# Altium schematic sheet REUN.468349.001_PSU_Board_Logic.SchDoc
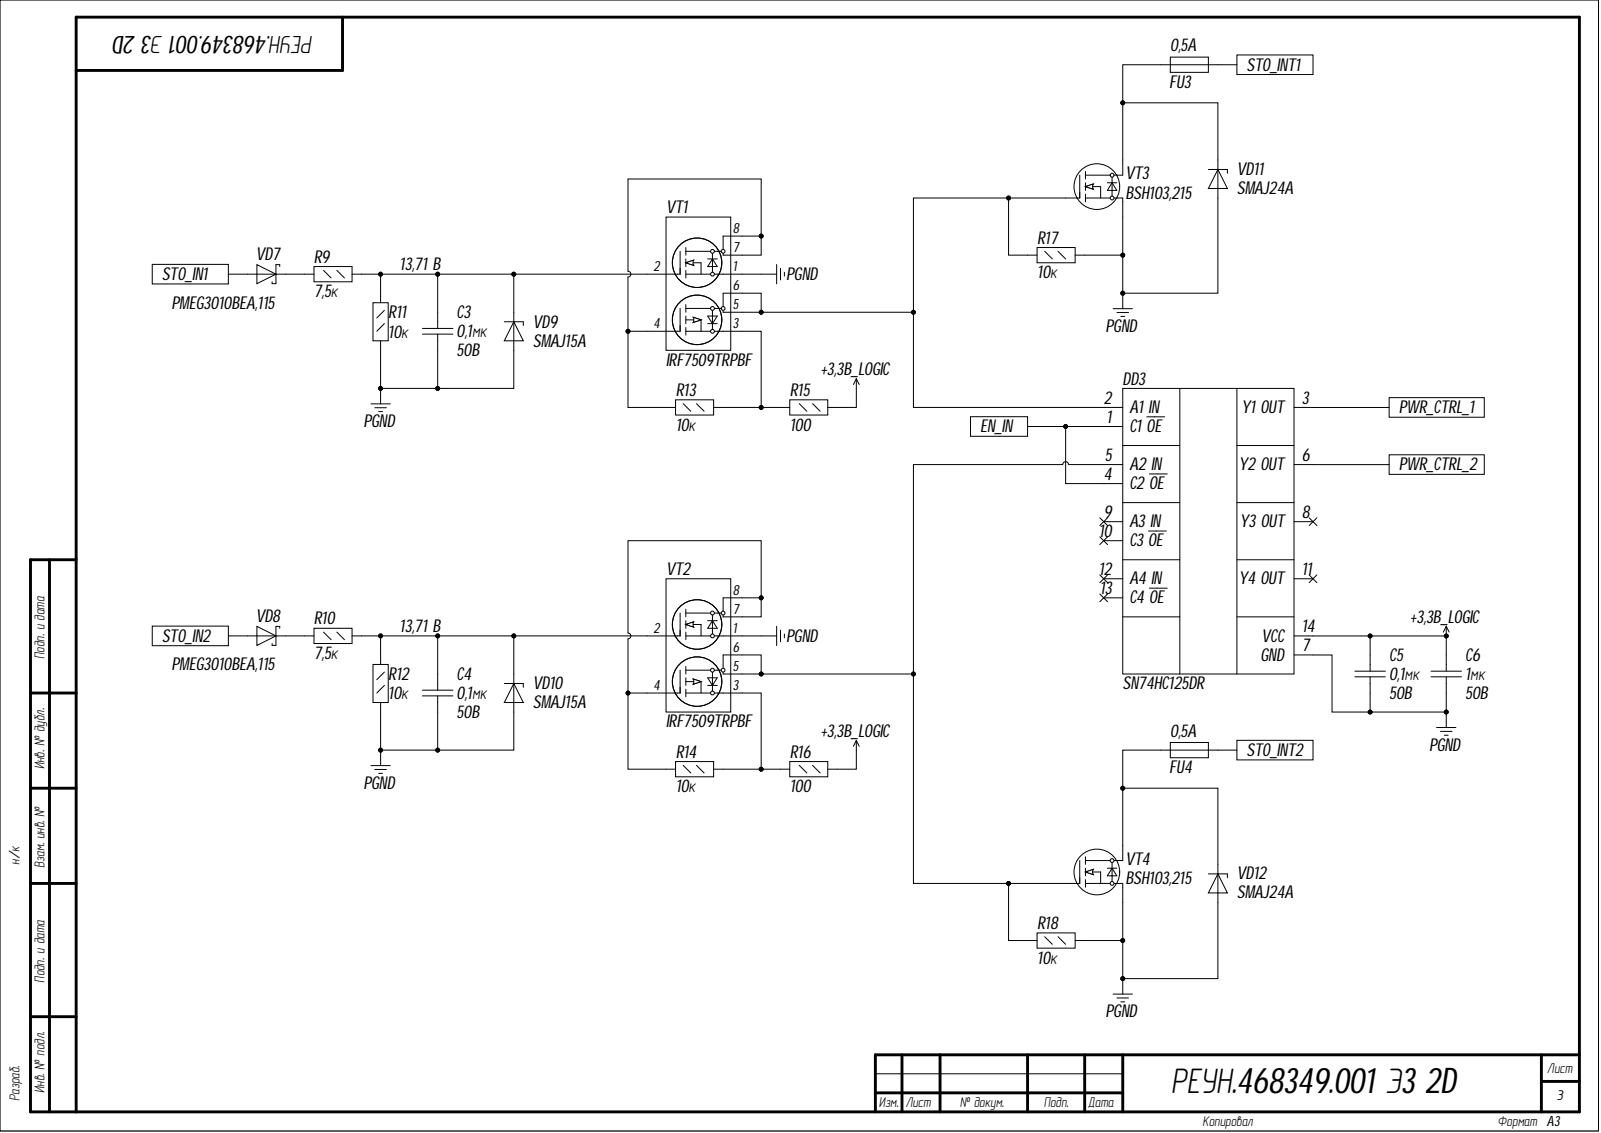
[diagram p0: REUN.468349.001_PSU_Board_Logic.SchDoc]

## Parts
C3  0,1мк  Voltage: 50B  [C0805]
C4  0,1мк  Voltage: 50B  [C0805]
C5  0,1мк  Voltage: 50B  [C0805]
C6  1мк  Voltage: 50B  [C0805]
DD3  SN74HC125DR  [SO14]
FU3  I hold: 0,5A  [FUSE812]  (hidden comment: Fuse)
FU4  I hold: 0,5A  [FUSE812]  (hidden comment: Fuse)
R9  value 7,5к  [R0805]  (hidden comment: Resistor_0,125Вт)
R10  value 7,5к  [R0805]  (hidden comment: Resistor_0,125Вт)
R11  value 10к  [R0805]  (hidden comment: Resistor_0,125Вт)
R12  value 10к  [R0805]  (hidden comment: Resistor_0,125Вт)
R13  value 10к  [R0805]  (hidden comment: Resistor_0,125Вт)
R14  value 10к  [R0805]  (hidden comment: Resistor_0,125Вт)
R15  value 100  [R0805]  (hidden comment: Resistor_0,125Вт)
R16  value 100  [R0805]  (hidden comment: Resistor_0,125Вт)
R17  value 10к  [R0805]  (hidden comment: Resistor_0,125Вт)
R18  value 10к  [R0805]  (hidden comment: Resistor_0,125Вт)
VD7  PMEG3010BEA,115  [SOD-323]
VD8  PMEG3010BEA,115  [SOD-323]
VD9  SMAJ15A  [SMA]
VD10  SMAJ15A  [SMA]
VD11  SMAJ24A  [SMA]
VD12  SMAJ24A  [SMA]
VT1  IRF7509TRPBF  [Micro8]
VT2  IRF7509TRPBF  [Micro8]
VT3  BSH103,215  [SOT23-3]
VT4  BSH103,215  [SOT23-3]

## Ports
EN_IN, PWR_CTRL_1, PWR_CTRL_2, STO_IN1, STO_IN2, STO_INT1, STO_INT2

## Nets
+3,3B_LOGIC: C5.2 C6.2 DD3.14(VCC) R15.1 R16.1
PGND: C3.1 C4.1 C5.1 C6.1 DD3.7(GND) R11.2 R12.2 R17.2 R18.2 VD9.2 VD10.2 VD11.2 VD12.2 VT1.1 VT2.1 VT3.2 VT4.2
EN_IN: DD3.1(C1_OE) DD3.4(C2_OE) ; port EN_IN
PWR_CTRL_1: DD3.3(Y1_OUT) ; port PWR_CTRL_1
PWR_CTRL_2: DD3.6(Y2_OUT) ; port PWR_CTRL_2
STO_IN1: VD7.2 ; port STO_IN1
STO_IN2: VD8.2 ; port STO_IN2
STO_INT1: FU3.2 ; port STO_INT1
STO_INT2: FU4.2 ; port STO_INT2
NetC3_2: C3.2 R9.1 R11.1 VD9.1 VT1.2
NetC4_2: C4.2 R10.1 R12.1 VD10.1 VT2.2
NetDD3_2: DD3.2(A1_IN) R17.1 VT1.5 VT1.6 VT3.1
NetDD3_5: DD3.5(A2_IN) R18.1 VT2.5 VT2.6 VT4.1
NetFU3_1: FU3.1 VD11.1 VT3.3
NetFU4_1: FU4.1 VD12.1 VT4.3
NetR9_2: R9.2 VD7.1
NetR10_2: R10.2 VD8.1
NetR13_1: R13.1 VT1.4 VT1.7 VT1.8
NetR13_2: R13.2 R15.2 VT1.3
NetR14_1: R14.1 VT2.4 VT2.7 VT2.8
NetR14_2: R14.2 R16.2 VT2.3

Not connected: DD3 8(Y3_OUT) 9(A3_IN) 10(C3_OE) 11(Y4_OUT) 12(A4_IN) 13(C4_OE)

## Library data of the parts
C3-C5 = cap141: Конденсатор; Manufacture: Murata; Part Name: Ceramic; Part Number: GRM21BR71H104KA01K; Database Table Name: Capacitors$; TKE: X7R; Component Kind: Standard; id: cap141; Library Name: MOP44.DbLib; Value: 0,1мк
C6 = cap151: Конденсатор; Manufacture: Murata; Part Name: Ceramic; Part Number: GRM21BR71H105KA12L; Database Table Name: Capacitors$; TKE: X7R; Component Kind: Standard; id: cap151; Library Name: MOP44.DbLib; Value: 1мк
DD3 = logic16: Quad Tri-State Buffer IC; Manufacture: Nexperia; Database Table Name: Logic$; Input High Level: 1,75B; Input Low Level: 1,55B; Number of elements: 4; Output High Level: 3,3B; Output Low Level: 0,1B; Power Supply: 2В-6В; Toff: 41ns; Type of Logic: 3V3 CMOS; Component Kind: Standard; id: logic16; Library Name: MOP44.DbLib; Part Name: Quad Tri-State Buffer; Part Number: SN74HC125DR; Temperature: -40...+125; Ton: 41ns
FU3 FU4 = fuse8: Предохранитель; Manufacture: Bourns; Part Name: Resettable Fuses; Part Number: MF-MSMF050; Database Table Name: Fuse$; I max: 100A; I trip: 1A; Resistance: 0,15Ohms; V max: 15B; Component Kind: Standard; id: fuse8; Library Name: MOP44.DbLib
R9 R10 = res98: Резистор; Manufacture: Yageo; Part Number: RC0805FR-077K5; Accuracy: 0.01; Database Table Name: Resistor$; Component Kind: Standard; id: res98; Library Name: MOP44.DbLib; Part Name: Resistor; Power: 0,125Вт
R11-R14 R17 R18 = res101: Резистор; Manufacture: Yageo; Part Number: RC0805FR-0710KL; Accuracy: 0.01; Database Table Name: Resistor$; Component Kind: Standard; id: res101; Library Name: MOP44.DbLib; Part Name: Resistor; Power: 0,125Вт
R15 R16 = res49: Резистор; Manufacture: Yageo; Part Number: RC0805FR-07100RL; Accuracy: 0.01; Database Table Name: Resistor$; Component Kind: Standard; id: res49; Library Name: MOP44.DbLib; Part Name: Resistor; Power: 0,125Вт
VD7 VD8 = diode63: Диод Шоттки; Manufacture: NXP Semiconductor; Part Name: Diode Schottky; Database Table Name: Diode$; Forward Current: 1А; Forward Voltage: 0,13B; Max Reverse Voltage: 30B; Number: 1; Peak Current: 10A; Reverse Current: 1,5mA; Component Kind: Standard; id: diode63; Library Name: MOP44.DbLib; Part Number: PMEG3010BEA,115; Temp MAX: +150; Temp MIN: -65
VD9 VD10 = SMAJ15A: Cтабилитрон (лавинный выпрямительный диод) односторонний; Manufacture: ST Microelectronics; Part Name: TVS diode Unidirectional; Breakdown Voltage: 17,6B; Clamping voltage: 24,4B; Database Table Name: TVSdiode$; Dynamic resistance: 0,361Ohm; id: tvs130; Leakage Current: 0,2uA; Number of diodes: 1; Peak Pulse Power: 400W; Stand-off Voltage: 15B; Component Kind: Standard; Library Name: MOP44.DbLib; Part Number: SMAJ15A
VD11 VD12 = SMAJ24A: Cтабилитрон (лавинный выпрямительный диод) односторонний; Manufacture: ST Microelectronics; Part Name: TVS diode Unidirectional; Breakdown Voltage: 28,1B; Clamping voltage: 38,9B; Database Table Name: TVSdiode$; Dynamic resistance: 0,912Ohm; id: tvs134; Leakage Current: 0,2uA; Number of diodes: 1; Peak Pulse Power: 400W; Stand-off Voltage: 24B; Component Kind: Standard; Library Name: MOP44.DbLib; Part Number: SMAJ24A
VT1 VT2 = mosfert3: Комплементарная сборка МОП; Manufacturer: International Rectifier; Continuous drain current: 2A; Database Table Name: MOSFETTransistor$; Gate-to-source voltage: (+-)20B; Power dissipation: 1,25W; Pulsed drain current: 16A; Rds: 0,11 Ohm; Structure: n-p-channel; V drain-source max: 30B; Component Kind: Standard; id: mosfert3; Library Name: MOP44.DbLib; Part Number: IRF7509TRPBF
VT3 VT4 = mosfert36: N-MOSFET; Manufacturer: Nexperia; Continuous drain current: 0,85A; Gate-to-source voltage: (+-)8B; Power dissipation: 0,5W; Pulsed drain current: 3,4A; Rds: 0,5Ohm; Structure: n-channel; Total gate charge: 2100pC; V drain-source max: 30B; Component Kind: Standard; id: mosfert36; Part Number: BSH103,215; Library Name: REUN.468349.001_PSU_Board.SchLib
